# Altium schematic sheet IA.SchDoc(IA)
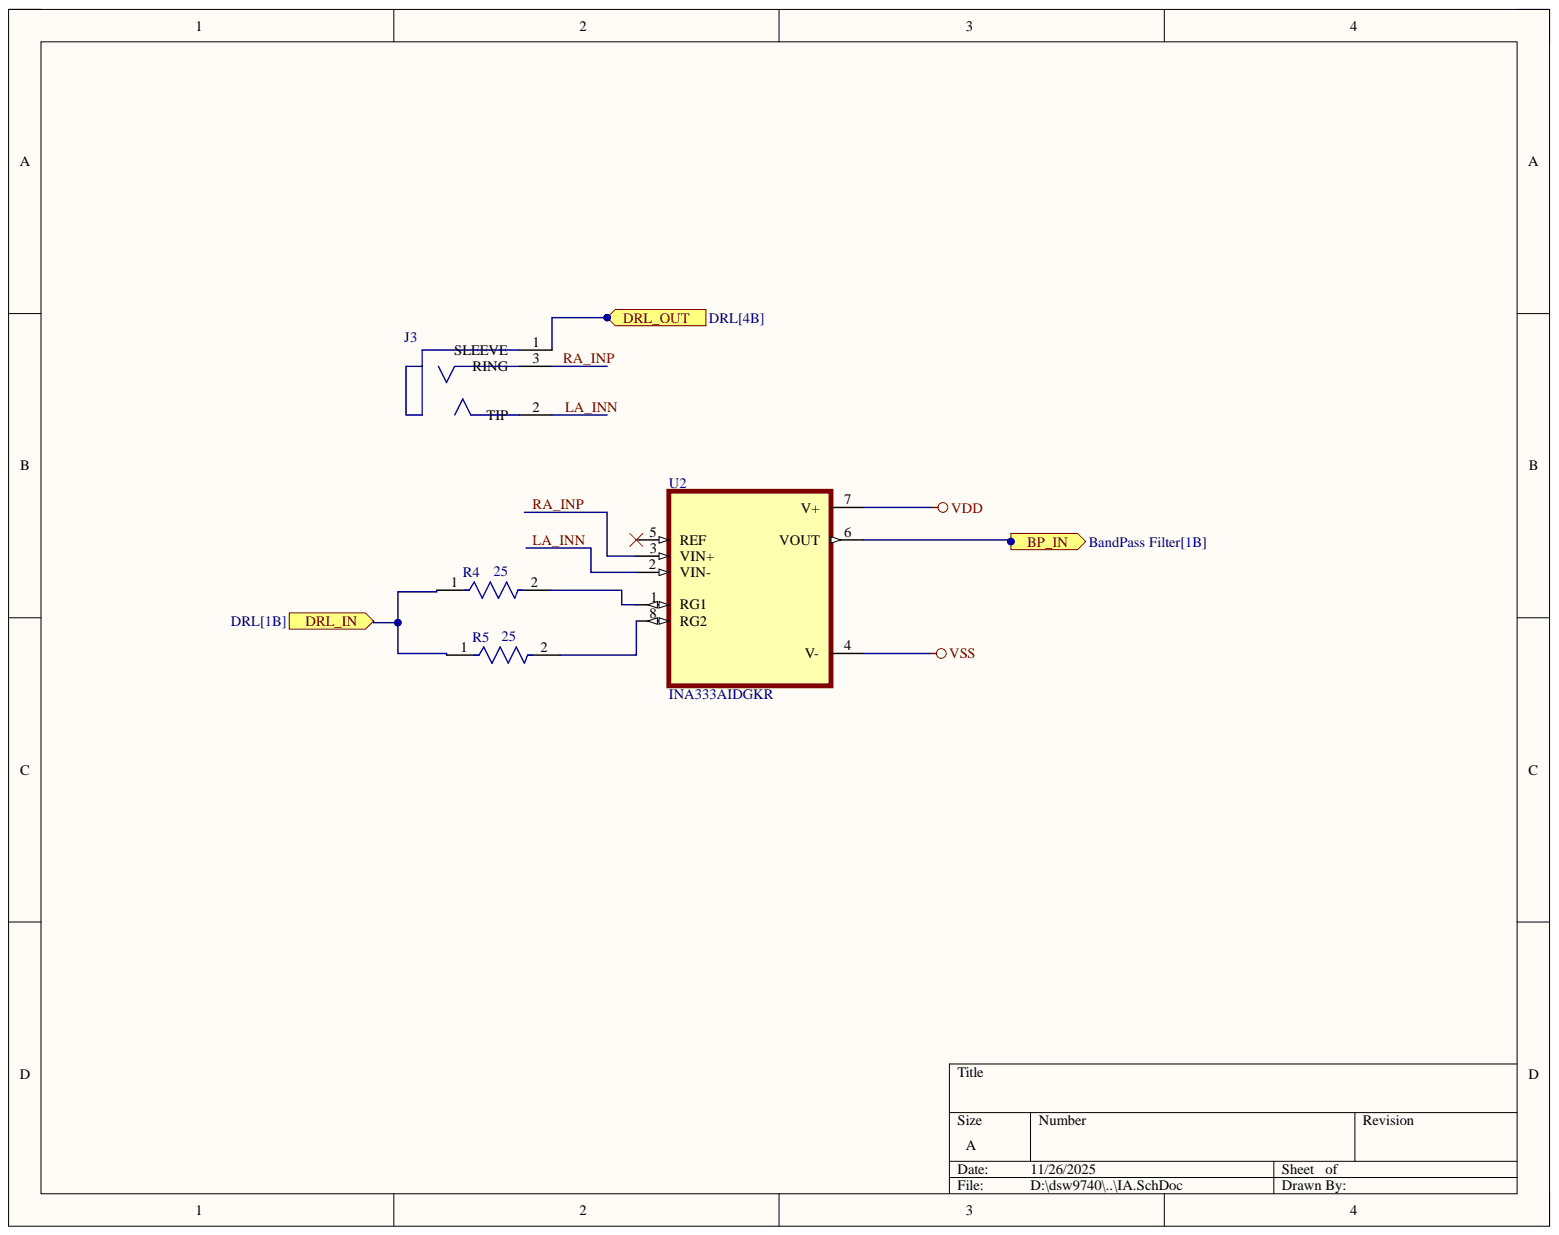
[diagram p0: IA.SchDoc]

## Parts
J3  SJ-3523-SMT-TR  [CUI_SJ-3523-SMT-TR]  (value not drawn)
R4  CRCW080516R0FKEA  [FP-CRCW0805-e3-IPC_A]  (value not drawn)
R5  CRCW080516R0FKEA  [FP-CRCW0805-e3-IPC_A]  (value not drawn)
U2  INA333AIDGKR  [SOP65P490X110-8N]

## Ports
BP_IN, DRL_IN, DRL_OUT

## Nets
VDD: U2.7(V+)
VSS: U2.4(V-)
BP_IN: U2.6(VOUT) ; port BP_IN
DRL_IN: R4.1 R5.1 ; port DRL_IN
DRL_OUT: J3.1 ; port DRL_OUT
LA_INN: J3.2 U2.2(VIN-)
RA_INP: J3.3 U2.3(VIN+)
NetR4_2: R4.2 U2.1(RG1)
NetR5_2: R5.2 U2.8(RG2)

Not connected: U2 5(REF)

## Library data of the parts
J3 = SJ-3523-SMT-TR: 3.5 mm, Stereo, Right Angle, Surface Mount (SMT), 3 Conductors, 0 Internal Switches, Audio Jack Connector; MF: Same Sky; Check_prices: https://www.snapeda.com/parts/SJ-3523-SMT-TR/Same+Sky/view-part/?ref=eda; Component Kind: Standard; Library Name: SJ-3523-SMT-TR.SchLib; MP: SJ-3523-SMT-TR; Package: None; SnapEDA_Link: https://www.snapeda.com/parts/SJ-3523-SMT-TR/Same+Sky/view-part/?ref=snap
R4 R5 = CMP-02407-002795-1: RES Thick Film, 16Ω, 1%, 0.125W, 100ppm/°C, 0805; Max Power Dissipation: 125mW; Resistance: 16R; Voltage Rating: 150V; Case Code (Imperial): 0805; Case Code (Metric): 2012; Case/Package: 0805; Component Kind: Standard; Composition: Thick Film; Contact Plating: Tin; Depth: 1.25mm; Features: Automotive AEC-Q200; Height: 0.02inch; Lead Free: Lead Free; Length: 2mm; Library Name: Altium Content Vault; Max Operating Temperature: 155°C; Military Standard: Not; Min Operating Temperature: -55°C; Number of Pins: 2; Number of Terminations: 2; Package Quantity: 10; Packaging: Tape and Reel; Power Rating: 125mW; Radiation Hardening: No; Temperature Coefficient: 100ppm/°C; Termination: SMD/SMT; Tolerance: 1%; Voltage Rating (DC): 150V; Weight: 0.000194oz; Width: 0.049inch
U2 = INA333AIDGKR: Low-Power, Zero-Drift, Precision Instrumentation Amplifier; MF: Texas Instruments; Check_prices: https://www.snapeda.com/parts/INA333AIDGKR/Texas+Instruments/view-part/?ref=eda; Component Kind: Standard; Library Name: INA333AIDGKR.SchLib; MP: INA333AIDGKR; Package: VSSOP-8 Texas Instruments; SnapEDA_Link: https://www.snapeda.com/parts/INA333AIDGKR/Texas+Instruments/view-part/?ref=snap
